# Altium schematic sheet Connector.SchDoc(Connector)
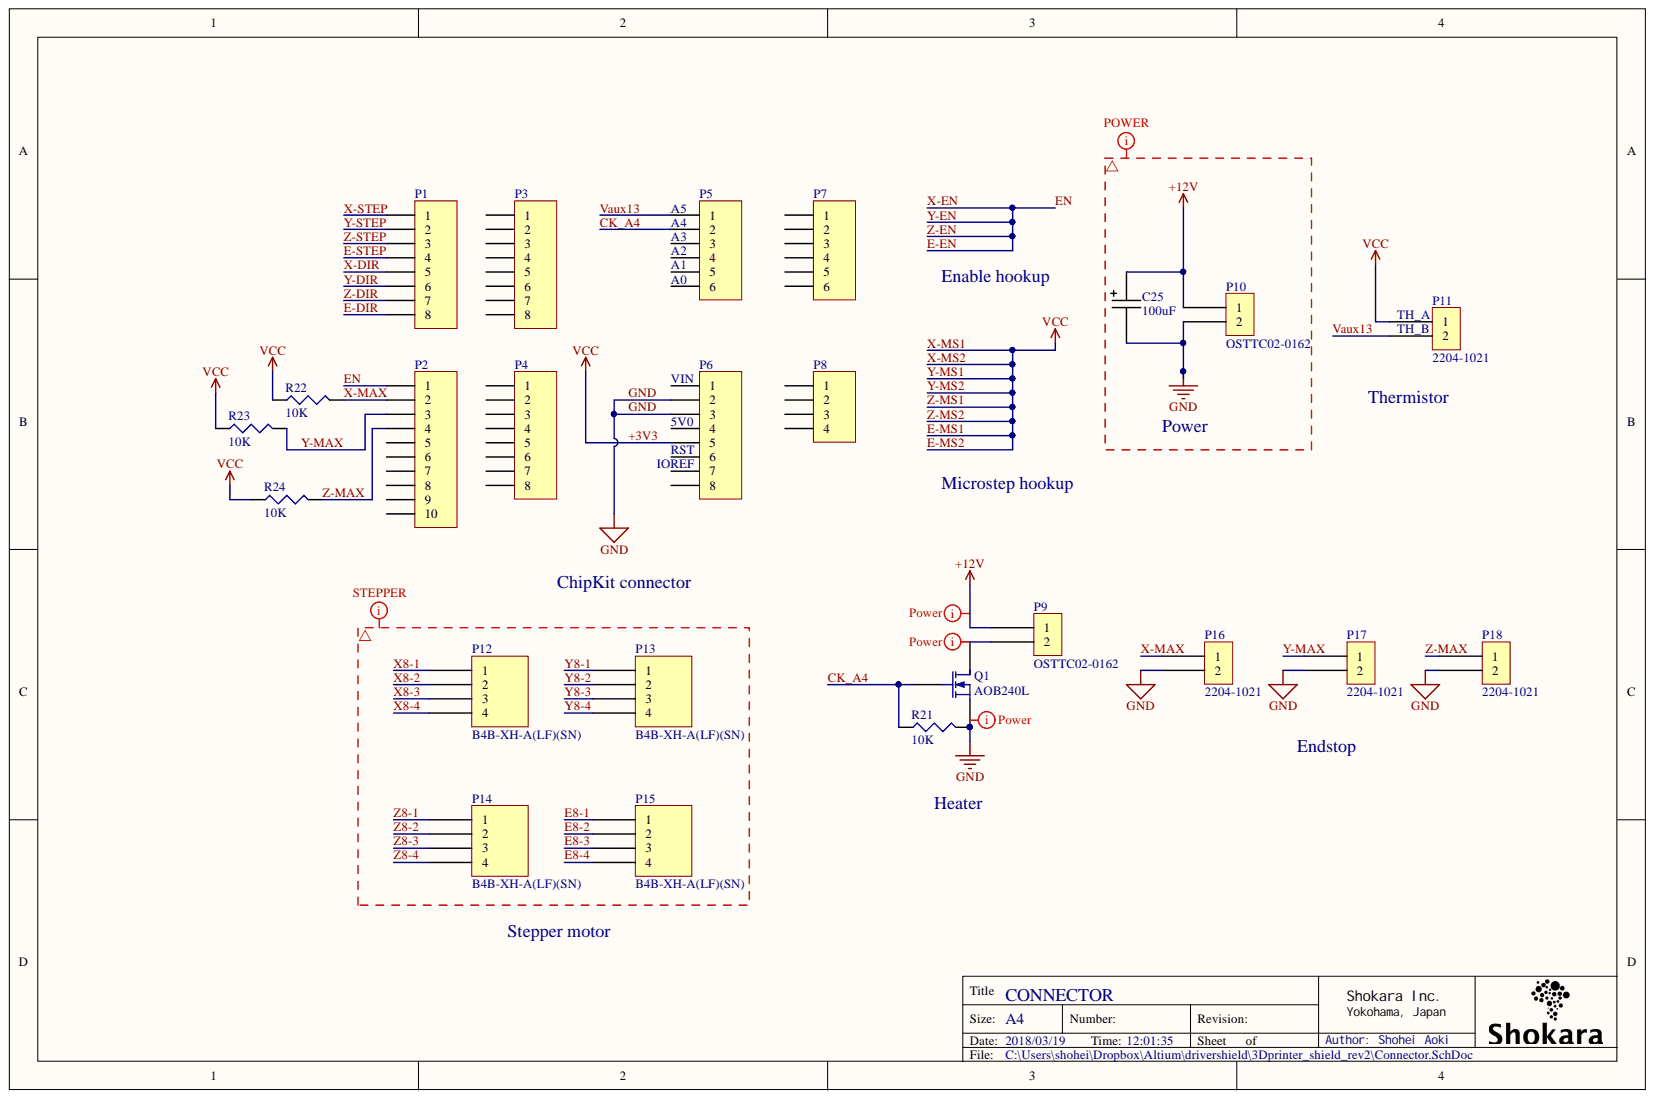
[diagram p0: Connector.SchDoc]

## Parts
C25  (no value)  [EEE-1HA470XP]
P1  (no value)  [HDR1X8]
P2  (no value)  [HDR1X10]
P3  (no value)  [HDR1X8]
P4  (no value)  [HDR1X8]
P5  (no value)  [HDR1X6]
P6  (no value)  [HDR1X8]
P7  (no value)  [HDR1X6]
P8  (no value)  [HDR1X4]
P9  OSTTC02-0162  [OSTTC02-0162]
P10  OSTTC02-0162  [OSTTC02-0162]
P11  2204-1021  [MOLEX_2204_1021]
P12  B4B-XH-A(LF)(SN)  [B4B-XH-A]
P13  B4B-XH-A(LF)(SN)  [B4B-XH-A]
P14  B4B-XH-A(LF)(SN)  [B4B-XH-A]
P15  B4B-XH-A(LF)(SN)  [B4B-XH-A]
P16  2204-1021  [MOLEX_2204_1021]
P17  2204-1021  [MOLEX_2204_1021]
P18  2204-1021  [MOLEX_2204_1021]
Q1  AOB240L  [DPAK254P1524X483-3N]
R21  value 10K  [J1-0603]
R22  value 10K  [J1-0603]
R23  value 10K  [J1-0603]
R24  value 10K  [J1-0603]

## Nets
+12V: C25.2 P9.1 P10.1
+3V3: P6.5 P11.1 R22.1 R23.1 R24.1
CK_A4: P5.2 Q1.1 R21.1
E8-1: P15.1
E8-2: P15.2
E8-3: P15.3
E8-4: P15.4
E-DIR: P1.8
E-EN: P2.1
E-STEP: P1.4
GND: C25.1 P6.2 P6.3 P10.2 P16.2 P17.2 P18.2 Q1.3 R21.2
Vaux13: P5.1 P11.2
X8-1: P12.1
X8-2: P12.2
X8-3: P12.3
X8-4: P12.4
X-DIR: P1.5
X-MAX: P2.2 P16.1 R22.2
X-STEP: P1.1
Y8-1: P13.1
Y8-2: P13.2
Y8-3: P13.3
Y8-4: P13.4
Y-DIR: P1.6
Y-MAX: P2.3 P17.1 R23.2
Y-STEP: P1.2
Z8-1: P14.1
Z8-2: P14.2
Z8-3: P14.3
Z8-4: P14.4
Z-DIR: P1.7
Z-MAX: P2.4 P18.1 R24.2
Z-STEP: P1.3
NetP9_2: P9.2 Q1.4
N00012:
N00013:
N00014:

Not connected: P2 5 6 7 8 9 10; P3 1 2 3 4 5 6 7 8; P4 1 2 3 4 5 6 7 8; P5 3 4 5 6; P6 1 4 6 7 8; P7 1 2 3 4 5 6; P8 1 2 3 4

## Library data of the parts
C25 = Cap_alumi_electrolytic: Component Kind: Standard; : 100uF; Library Name: 3dprinter_shield.SchLib
P1 P3 P4 P6 = Header 8: Header, 8-Pin; Signal Integrity: Connector; Library Name: Miscellaneous Connectors.IntLib; LatestRevisionNote: Re-released for DXP Platform.; LatestRevisionDate: 17-Jul-2002; Component Kind: Standard; Publisher: Altium Limited
P2 = Header 10: Header, 10-Pin; Signal Integrity: Connector; Library Name: Miscellaneous Connectors.IntLib; LatestRevisionNote: Re-released for DXP Platform.; LatestRevisionDate: 17-Jul-2002; Component Kind: Standard; Publisher: Altium Limited
P5 P7 = Header 6: Header, 6-Pin; Signal Integrity: Connector; Library Name: Miscellaneous Connectors.IntLib; LatestRevisionNote: Re-released for DXP Platform.; LatestRevisionDate: 17-Jul-2002; Component Kind: Standard; Publisher: Altium Limited
P8 = Header 4: Header, 4-Pin; Signal Integrity: Connector; Library Name: Miscellaneous Connectors.IntLib; LatestRevisionNote: Re-released for DXP Platform.; LatestRevisionDate: 17-Jul-2002; Component Kind: Standard; Publisher: Altium Limited
P9 P10 = TERMINAL_BLOCK_1X2: Component Kind: Standard; Library Name: 3dprinter_shield.SchLib
P11 P16-P18 = MOLEX_1X2_HEADER: Component Kind: Standard; Library Name: 3dprinter_shield.SchLib
P12-P15 = XH_CONNECTOR_1X4: Component Kind: Standard; Library Name: 3dprinter_shield.SchLib
R21-R24 = Res3: Resistor; Simulation: RESISTOR; Signal Integrity: Res; Published: 8-Jun-2000; PackageReference: J1-0603; PackageDescription: Chip Resistor; Library Name: Miscellaneous Devices.IntLib; LatestRevisionNote: IPC-7351 Footprint Added.; LatestRevisionDate: 29-May-2009; Component Kind: Standard; Publisher: Altium Limited
Q1 = AOB240L: Component Kind: Standard; Library Name: 3dprinter_shield.SchLib
